# Altium schematic sheet MCU.SchDoc
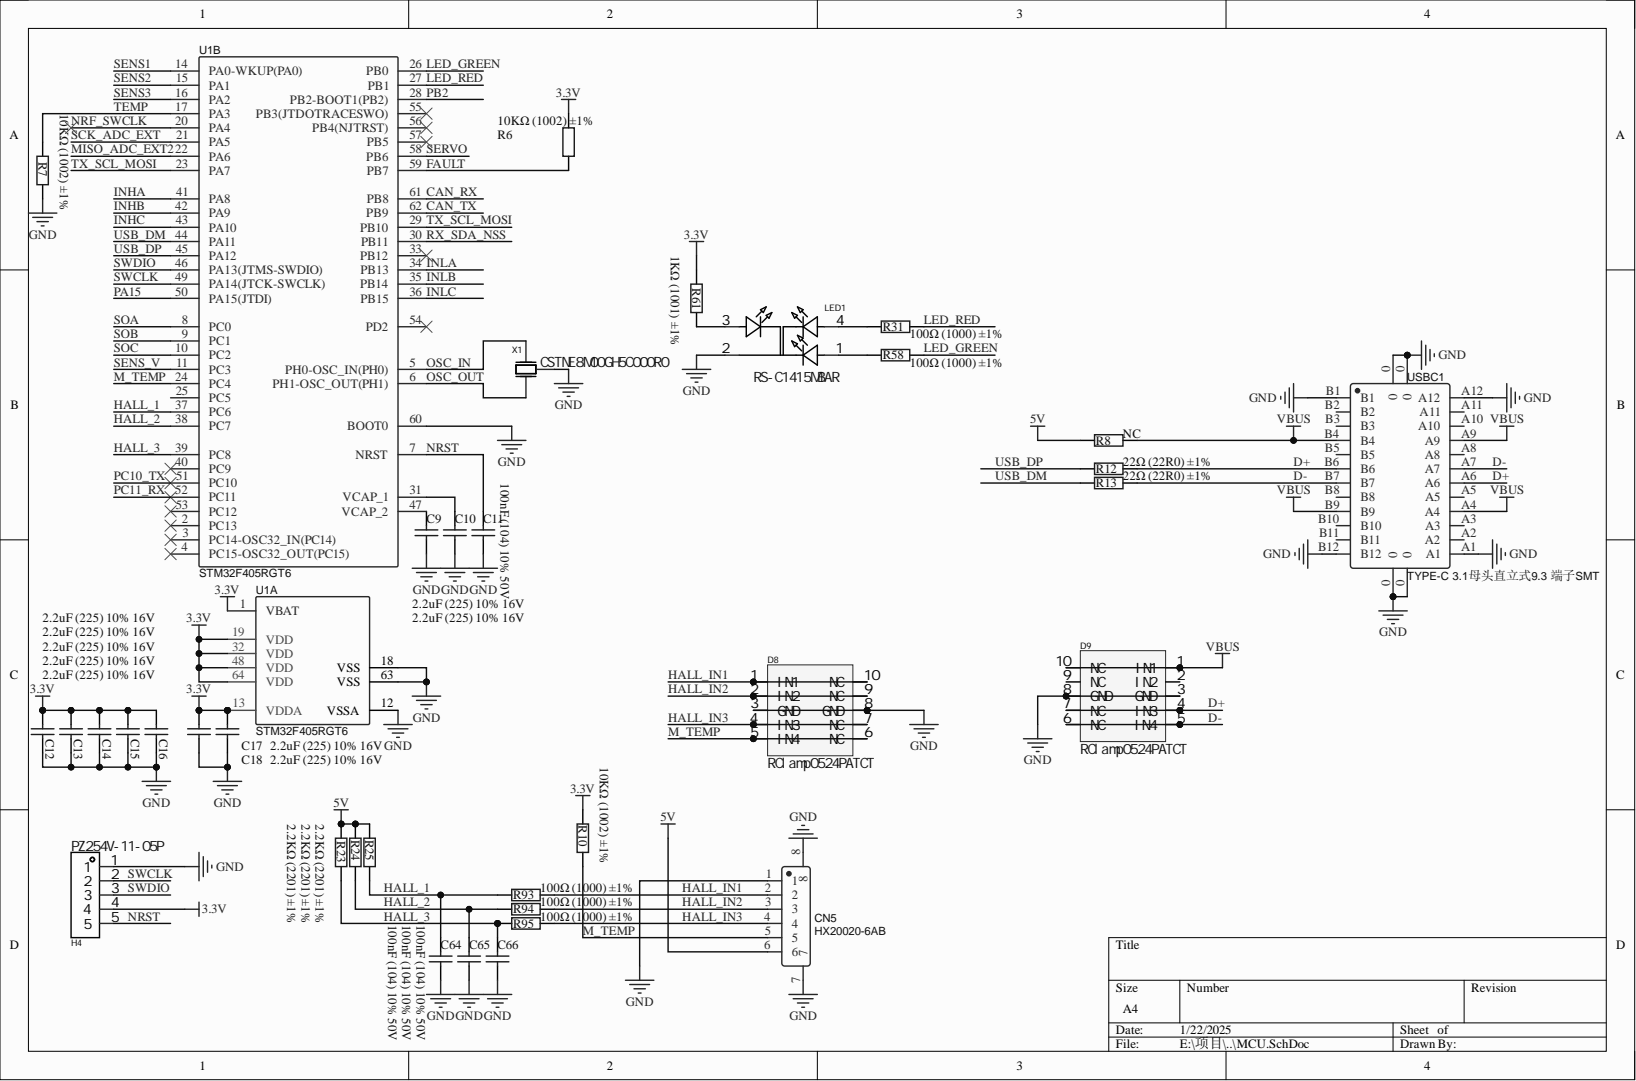
[diagram p0: MCU.SchDoc]

## Parts
C9  2.2uF (225) 10% 16V  [0603_C]
C10  2.2uF (225) 10% 16V  [0603_C]
C11  100nF (104) 10% 50V  [0603_C]
C12  2.2uF (225) 10% 16V  [0603_C]
C13  2.2uF (225) 10% 16V  [0603_C]
C14  2.2uF (225) 10% 16V  [0603_C]
C15  2.2uF (225) 10% 16V  [0603_C]
C16  2.2uF (225) 10% 16V  [0603_C]
C17  2.2uF (225) 10% 16V  [0603_C]
C18  2.2uF (225) 10% 16V  [0603_C]
C64  100nF (104) 10% 50V  [0603_C]
C65  100nF (104) 10% 50V  [0603_C]
C66  100nF (104) 10% 50V  [0603_C]
CN5  HX20020-6AB  [CONN-SMD_6P-P2.00_HX20020-6AB]
D8  RClamp0524PATCT  [UFDFN-10]
D9  RClamp0524PATCT  [UFDFN-10]
H4  PZ254V-11-05P  [HDR-TH_5P-P2.54-V-M]
LED1  RS-C1415MBAR  [LED-ARRAY-SMD_4P-L1.6-W1.6-BR-RD-CW]
R6  10KΩ (1002) ±1%  [0603_R]
R7  10KΩ (1002) ±1%  [0603_R]
R8  NC  [0603_R]
R10  10KΩ (1002) ±1%  [0603_R]
R12  22Ω (22R0) ±1%  [0603_R]
R13  22Ω (22R0) ±1%  [0603_R]
R23  2.2KΩ (2201) ±1%  [0603_R]
R24  2.2KΩ (2201) ±1%  [0603_R]
R25  2.2KΩ (2201) ±1%  [0603_R]
R31  100Ω (1000) ±1%  [0603_R]
R58  100Ω (1000) ±1%  [0603_R]
R61  1KΩ (1001) ±1%  [0603_R]
R93  100Ω (1000) ±1%  [0603_R]
R94  100Ω (1000) ±1%  [0603_R]
R95  100Ω (1000) ±1%  [0603_R]
U1  STM32F405RGT6  [LQFP-64_L10.0-W10.0-P0.50-LS12.0-BL]  (parts A,B)
USBC1  TYPE-C 3.1母头直立式9.3 端子SMT  [USB-C-SMD_TYPE-C-USB-2]
X1  CSTNE8M00GH5C000R0  [OSC-SMD_3P-L3.2-W1.3-P1.20-L]

## Nets
3.3V: C12.2 C13.2 C14.2 C15.2 C16.2 C17.2 C18.2 H4.4 R6.1 R10.2 R61.1 U1.1(VBAT) U1.13(VDDA) U1.19(VDD) U1.32(VDD) U1.48(VDD) U1.64(VDD)
5V: CN5.6 R8.2 R23.2 R24.2 R25.2
GND: C9.2 C10.2 C11.1 C12.1 C13.1 C14.1 C15.1 C16.1 C17.1 C18.1 C64.1 C65.1 C66.1 CN5.1 CN5.7 CN5.8 D8.3(GND) D8.8(GND) D9.3(GND) D9.8(GND) H4.1 LED1.2 R7.2 U1.12(VSSA) U1.18(VSS) U1.60(BOOT0) U1.63(VSS) USBC1.0 USBC1.A1 USBC1.A12 USBC1.B1 USBC1.B12 X1.2
VBUS: D9.1(IN1) D9.10(NC) R8.1 USBC1.A4 USBC1.A9 USBC1.B4 USBC1.B9
CAN_RX: U1.61(PB8)
CAN_TX: U1.62(PB9)
D+: D9.4(IN3) D9.7(NC) R12.2 USBC1.A6 USBC1.B6
D-: D9.5(IN4) D9.6(NC) R13.2 USBC1.A7 USBC1.B7
FAULT: R6.2 U1.59(PB7)
HALL_1: C64.2 R25.1 R93.1 U1.37(PC6)
HALL_2: C65.2 R24.1 R94.1 U1.38(PC7)
HALL_3: C66.2 R23.1 R95.1 U1.39(PC8)
HALL_IN1: CN5.2 D8.1(IN1) D8.10(NC) R93.2
HALL_IN2: CN5.3 D8.2(IN2) D8.9(NC) R94.2
HALL_IN3: CN5.4 D8.4(IN3) D8.7(NC) R95.2
INHA: U1.41(PA8)
INHB: U1.42(PA9)
INHC: U1.43(PA10)
INLA: U1.34(PB13)
INLB: U1.35(PB14)
INLC: U1.36(PB15)
LED_GREEN: R58.2 U1.26(PB0)
LED_RED: R31.2 U1.27(PB1)
M_TEMP: CN5.5 D8.5(IN4) D8.6(NC) R10.1 U1.24(PC4)
MISO_ADC_EXT2: U1.22(PA6)
NRF_SWCLK: U1.20(PA4)
NRST: C11.2 H4.5 U1.7(NRST)
OSC_IN: U1.5(PH0-OSC_IN(PH0)) X1.1
OSC_OUT: U1.6(PH1-OSC_OUT(PH1)) X1.3
PA15: U1.50(PA15(JTDI))
PB2: U1.28(PB2-BOOT1(PB2))
PC10_TX: U1.51(PC10)
PC11_RX: U1.52(PC11)
RX_SDA_NSS: U1.30(PB11)
SCK_ADC_EXT: U1.21(PA5)
SENS1: U1.14(PA0-WKUP(PA0))
SENS2: U1.15(PA1)
SENS3: U1.16(PA2)
SENS_V: U1.11(PC3)
SERVO: U1.58(PB6)
SOA: U1.8(PC0)
SOB: U1.9(PC1)
SOC: U1.10(PC2)
SWCLK: H4.2 U1.49(PA14(JTCK-SWCLK))
SWDIO: H4.3 U1.46(PA13(JTMS-SWDIO))
TEMP: R7.1 U1.17(PA3)
TX_SCL_MOSI: U1.23(PA7) U1.29(PB10)
USB_DM: R13.1 U1.44(PA11)
USB_DP: R12.1 U1.45(PA12)
NetC9_1: C9.1 U1.47(VCAP_2)
NetC10_1: C10.1 U1.31(VCAP_1)
NetLED1_1: LED1.1 R58.1
NetLED1_3: LED1.3 R61.2
NetLED1_4: LED1.4 R31.1

Not connected: D9 2(IN2) 9(NC); U1 2(PC13) 3(PC14-OSC32_IN(PC14)) 4(PC15-OSC32_OUT(PC15)) 25(PC5) 33(PB12) 40(PC9) 53(PC12) 54(PD2) 55(PB3(JTDOTRACESWO)) 56(PB4(NJTRST)) 57(PB5); USBC1 A2 A3 A5 A8 A10 A11 B2 B3 B5 B8 B10 B11

## Library data of the parts
C9 C10 C12-C18 = 0603 2.2uF (225) 10% 16V: 贴片电容; Component Kind: Standard; ComponentLink1Description: 供应商链接; ComponentLink1URL: http://www.szlcsc.com/product/details_24366.html; Library Name: Cap.SchLib; Package: 0603; Supplier: LC; SuppliersPartNumber: C23630
C11 C64-C66 = 0603 100nF (104) 10% 50V: 贴片电容; Component Kind: Standard; ComponentLink1Description: 供应商链接; ComponentLink1URL: http://www.szlcsc.com/product/details_15331.html; Library Name: Cap.SchLib; Package: 0603; Supplier: LC; SuppliersPartNumber: C14663
CN5 = HX20020-6AB: BOM_Manufacturer: HX(Zhejiang Yueqing Hongxing Elec); Contributor: LCSC; BOM_JLCPCB Part Class: Extended Part; BOM_Manufacturer Part: HX20020-6AB; BOM_Supplier: LCSC; BOM_Supplier Part: C442273; Component Kind: Standard; spicePre: C; spiceSymbolName: HX20020-6AB
D8 D9 = RClamp0524PATCT: Manufacturer: SEMTECH; Add into BOM: yes; Breakdown Voltage (VBR): 6V; Clamping Voltage@Ipp: 15V; Device: RClamp0524PATCT_C40960; Junction Capacitance(Cj)@1MHz: 0.8pF@1MHz; Number of Lines: 4; Peak Pulse Power(Ppp)@8/20us: 150W; Reverse Stand-Off Voltage (Vrwm): 5V; Component Kind: Standard; Convert to PCB: yes; Datasheet: https://atta.szlcsc.com/upload/public/pdf/source/20150124/1457707260739.pdf; JLCPCB Part Class: Extended Part; LCSC Part Name: RClamp0524PATCT; Library Name: DVC1124_20S.SchLib; Manufacturer Part: RClamp0524PATCT; Name: RClamp0524PATCT; Operating Temperature: -55℃~+125℃@(Tj); Supplier: LCSC; Supplier Footprint: SLP2510-8; Supplier Part: C40960; Symbol: RClamp0524PATCT
H4 = PZ254V-11-05P: Manufacturer: XFCN(兴飞); Add into BOM: yes; Circluar Pins/Square Pins: 方针; Pin Structure: 1x5P; Pitch: 2.54mm; Color: 黑色; Component Kind: Standard; Contact Material: 黄铜; Contact Plating: -; Convert to PCB: yes; Current Rating (Max): -; Datasheet: https://atta.szlcsc.com/upload/public/pdf/source/20200309/C492404_E51533260F8710EFF5D4617281164C1D.pdf; Device: PZ254V-11-05P; Insulation Height: 2.5mm; JLCPCB Part Class: Extended Part; LCSC Part Name: 间距:2.54mm 1x5P 直插 方针; Length of End Connection Pin: 3mm; Length of Mating Pin: 6mm; Manufacturer Part: PZ254V-11-05P; Mounting Type: 直插; Number of Pins: 5P; Number of Rows: 单排; Operating Temperature Range: -40℃~+105℃; Row Spacing: -; Supplier: LCSC; Supplier Footprint: 插件,P=2.54mm; Supplier Part: C492404; Symbol: PZ254V-11-05P; Voltage Rating (Max): -
LED1 = RS-C1415MBAR: Manufacturer: 国星光电; Add into BOM: yes; Diode Configuration: 共阴极; Dominant Wavelength: 470nm；528nm；622nm; Emitted Color: RGB三色; Forward Current: 15mA；8mA；5mA; Forward Voltage (VF): 2.7V；2.6V；2.1V; Luminous Intensity: 50mcd；400mcd；360mcd; Power Dissipation: 68mW；85mW；60mW; Viewing Angle: 110°; Color Temperature: -; Component Kind: Standard; Convert to PCB: yes; Datasheet: https://atta.szlcsc.com/upload/public/pdf/source/20210810/A586D2E1898BE800E158AAE319DECFD4.pdf; Device: RS-C1415MBAR; JLCPCB Part Class: Extended Part; LCSC Part Name: RS-C1415MBAR; Lens Color: -; Manufacturer Part: RS-C1415MBAR; Mounting Sytle: -; Operating Temperature: -30℃~+85℃; Peak Wavelength: -; Supplier: LCSC; Supplier Footprint: 0606; Supplier Part: C2874181; Symbol: RS-C1415MBAR
R6 R7 R10 = 0603 10KΩ (1002) 1%: 贴片电阻; Component Kind: Standard; ComponentLink1Description: 供应商链接; ComponentLink1URL: http://www.szlcsc.com/product/details_26547.html; Library Name: Miscellaneous Devices LC.IntLib; Package: 0603; Supplier: LC; SuppliersPartNumber: C25804
R8 = 0402 0Ω (0R0) 1%: 贴片电阻; Component Kind: Standard; ComponentLink1Description: 供应商链接; ComponentLink1URL: http://www.szlcsc.com/product/details_17853.html; Library Name: RES.SchLib; Package: 0402; Supplier: LC; SuppliersPartNumber: C17168
R12 R13 = 0603 22Ω (22R0) 1%: 贴片电阻; Component Kind: Standard; ComponentLink1Description: 供应商链接; ComponentLink1URL: http://www.szlcsc.com/product/details_24078.html; Library Name: RES.SchLib; Package: 0603; Supplier: LC; SuppliersPartNumber: C23345
R23-R25 = 0603 2.2KΩ (2201) 1%: 贴片电阻; Component Kind: Standard; ComponentLink1Description: 供应商链接; ComponentLink1URL: http://www.szlcsc.com/product/details_4597.html; Library Name: RES.SchLib; Package: 0603; Supplier: LC; SuppliersPartNumber: C4190
R31 R58 R93-R95 = 0603 100Ω (1000) 1%: 贴片电阻; Component Kind: Standard; ComponentLink1Description: 供应商链接; ComponentLink1URL: http://www.szlcsc.com/product/details_23502.html; Library Name: RES.SchLib; Package: 0603; Supplier: LC; SuppliersPartNumber: C22775
R61 = 0402 1KΩ (1001) 1%: 贴片电阻; Component Kind: Standard; ComponentLink1Description: 供应商链接; ComponentLink1URL: http://www.szlcsc.com/product/details_12256.html; Library Name: RES.SchLib; Package: 0402; Supplier: LC; SuppliersPartNumber: C11702
U1 = STM32F405RGT6: BOM_Manufacturer: ST; subpart_no: 2; tags: STM32; BOM_Manufacturer Part: STM32F405RGT6; BOM_Supplier: LCSC; BOM_Supplier Part: C15742; Component Kind: Standard; image: http://image.lceda.cn/szlcsc/C15742.jpg; Library Name: BLDC.SchLib; spicePre: U; spiceSymbolName: STM32F405RGT6
USBC1 = TYPE-C-3.1-Female-TH-9.3: BOM_Manufacturer: LCSC; BOM_Manufacturer Part: -; BOM_Supplier: LCSC; BOM_Supplier Part: C168703; Component Kind: Standard; spicePre: U; spiceSymbolName: TYPE-C-3.1-Female-TH-9.3; Contributor: LCSC
X1 = CSTNE8M00GH5C000R0: Manufacturer: muRata(村田); Add into BOM: yes; Built-in Load Capacitance: 33pF; Resonant Impedance: 40Ω; Value: 8MHz; Component Kind: Standard; Convert to PCB: yes; Datasheet: https://atta.szlcsc.com/upload/public/pdf/source/20181211/C341525_832BA077079E4863A5153C1DB98581D5.pdf; Device: CSTNE8M00GH5C000R0; JLCPCB Part Class: Extended Part; LCSC Part Name: 8MHz ±0.07% 内置负载电容:33pF; Manufacturer Part: CSTNE8M00GH5C000R0; Operating Temperature: -40℃~+125℃; Supplier: LCSC; Supplier Footprint: SMD3213-3P; Supplier Part: C341525; Symbol: CSTNE8M00GH5C000R0
